# Altium schematic sheet Sata_Controll.SchDoc(Sata_Controll)
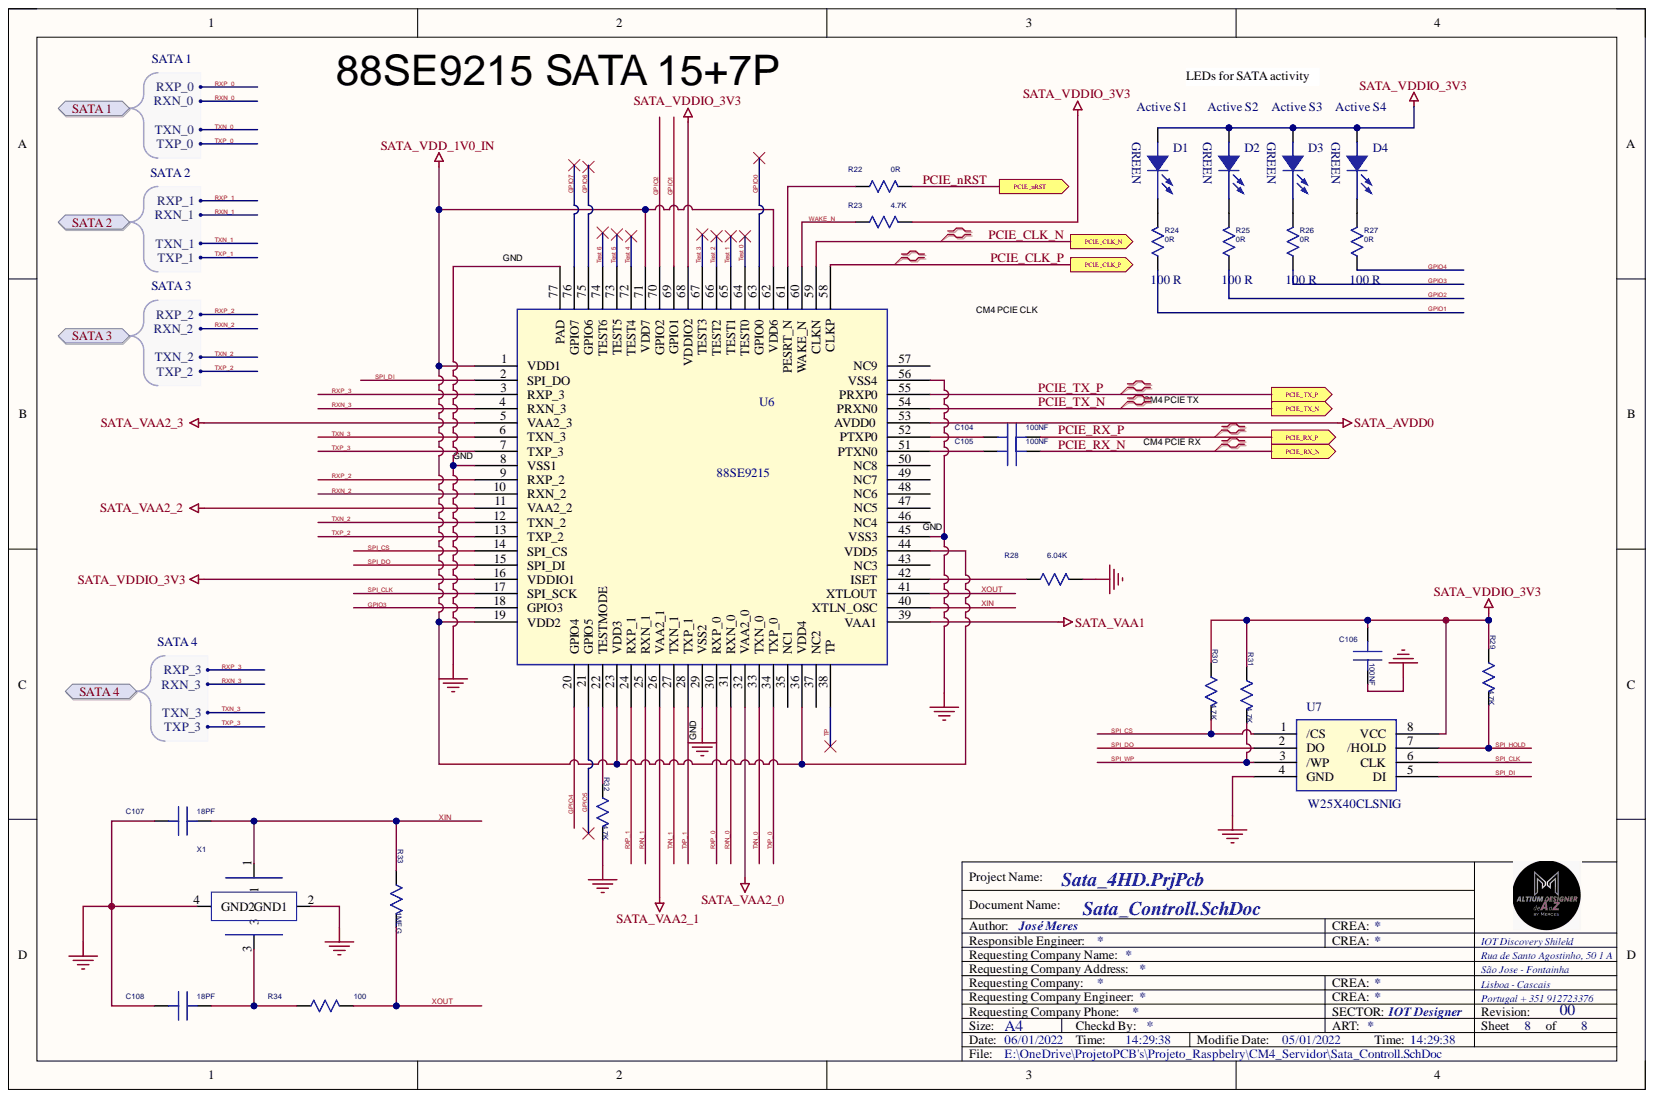
[diagram p0: Sata_Controll.SchDoc]

## Parts
C104  100NF  [C0402-ROUND]
C105  100NF  [C0402-ROUND]
C106  100NF  [C0402-ROUND]
C107  18PF  [C0402-ROUND]
C108  18PF  [C0402-ROUND]
D1  GREEN  [LED-0603]
D2  GREEN  [LED-0603]
D3  GREEN  [LED-0603]
D4  GREEN  [LED-0603]
R22  0R  [R0402-ROUND]
R23  4.7K  [R0402-ROUND]
R24  0R  value 100 R  [R0201-ROUND]
R25  0R  value 100 R  [R0201-ROUND]
R26  0R  value 100 R  [R0201-ROUND]
R27  0R  value 100 R  [R0201-ROUND]
R28  6.04K  [R0402-ROUND]
R29  4.7K  [R0402-ROUND]
R30  4.7K  [R0402-ROUND]
R31  4.7K  [R0402-ROUND]
R32  4.7K  [R0402-ROUND]
R33  1MEG  [R0402-ROUND]
R34  100  [R0402-ROUND]
U6  88SE9215  [Sata-88SE9215]
U7  W25X40CLSNIG  [SO08]
X1  25M  [HSX4-0302]  (value not drawn)

## Ports
PCIE_CLK_N, PCIE_CLK_P, PCIE_nRST, PCIE_RX_N, PCIE_RX_P, PCIE_TX_N, PCIE_TX_P, SATA 1, SATA 1.RXN_0, SATA 1.RXP_0, SATA 1.TXN_0, SATA 1.TXP_0, SATA 2, SATA 2.RXN_1, SATA 2.RXP_1, SATA 2.TXN_1, SATA 2.TXP_1, SATA 3, SATA 3.RXN_2, SATA 3.RXP_2, SATA 3.TXN_2, SATA 3.TXP_2, SATA 4, SATA 4.RXN_3, SATA 4.RXP_3, SATA 4.TXN_3, SATA 4.TXP_3

## Nets
GND: C106.2 C107.1 C108.1 R28.2 R32.2 U6.8(VSS1) U6.29(VSS2) U6.45(VSS3) U6.56(VSS4) U6.77(PAD) U7.4(GND) X1.2(GND1) X1.4(GND2)
SATA_AVDD0: U6.53(AVDD0)
SATA_VAA1: U6.39(VAA1)
SATA_VAA2_0: U6.32(VAA2_0)
SATA_VAA2_1: U6.26(VAA2_1)
SATA_VAA2_2: U6.11(VAA2_2)
SATA_VAA2_3: U6.5(VAA2_3)
SATA_VDD_1V0_IN: U6.1(VDD1) U6.19(VDD2) U6.23(VDD3) U6.36(VDD4) U6.44(VDD5) U6.62(VDD6) U6.71(VDD7)
SATA_VDDIO_3V3: C106.1 D1.1 D2.1 D3.1 D4.1 R23.2 R29.1 R30.1 R31.1 U6.16(VDDIO1) U6.68(VDDIO2) U7.8(VCC)
GPIO0: U6.63(GPIO0)
GPIO1: R24.1 U6.69(GPIO1)
GPIO2: R25.1 U6.70(GPIO2)
GPIO3: R26.1 U6.18(GPIO3)
GPIO4: R27.1 U6.20(GPIO4)
GPIO5: U6.21(GPIO5)
GPIO6: U6.75(GPIO6)
GPIO7: U6.76(GPIO7)
PCIE_CLK_N: U6.59(CLKN) ; port PCIE_CLK_N
PCIE_CLK_P: U6.58(CLKP) ; port PCIE_CLK_P
PCIE_nRST: R22.2 ; port PCIE_nRST
PCIE_RX_N: C105.2 ; port PCIE_RX_N
PCIE_RX_P: C104.2 ; port PCIE_RX_P
PCIE_TX_N: U6.54(PRXN0) ; port PCIE_TX_N
PCIE_TX_P: U6.55(PRXP0) ; port PCIE_TX_P
RXN_0: U6.31(RXN_0) ; port SATA 1
RXN_1: U6.25(RXN_1) ; port SATA 2
RXN_2: U6.10(RXN_2) ; port SATA 3
RXN_3: U6.4(RXN_3) ; port SATA 4
RXP_0: U6.30(RXP_0) ; port SATA 1
RXP_1: U6.24(RXP_1) ; port SATA 2
RXP_2: U6.9(RXP_2) ; port SATA 3
RXP_3: U6.3(RXP_3) ; port SATA 4
SPI_CLK: U6.17(SPI_SCK) U7.6(CLK)
SPI_CS: R30.2 U6.14(SPI_CS) U7.1(/CS)
SPI_DI: U6.2(SPI_DO) U7.5(DI)
SPI_DO: U6.15(SPI_DI) U7.2(DO)
SPI_HOLD: R29.2 U7.7(/HOLD)
SPI_WP: R31.2 U7.3(/WP)
Test 0: U6.64(TEST0)
Test 1: U6.65(TEST1)
Test 2: U6.66(TEST2)
Test 3: U6.67(TEST3)
Test 4: U6.72(TEST4)
Test 5: U6.73(TEST5)
Test 6: U6.74(TEST6)
TP: U6.38(TP)
TXN_0: U6.33(TXN_0) ; port SATA 1
TXN_1: U6.27(TXN_1) ; port SATA 2
TXN_2: U6.12(TXN_2) ; port SATA 3
TXN_3: U6.6(TXN_3) ; port SATA 4
TXP_0: U6.34(TXP_0) ; port SATA 1
TXP_1: U6.28(TXP_1) ; port SATA 2
TXP_2: U6.13(TXP_2) ; port SATA 3
TXP_3: U6.7(TXP_3) ; port SATA 4
WAKE_N: R23.1 U6.60(WAKE_N)
XIN: C107.2 R33.1 U6.40(XTLN_OSC) X1.1
XOUT: R33.2 R34.2 U6.41(XTLOUT)
NetC104_1: C104.1 U6.52(PTXP0)
NetC105_1: C105.1 U6.51(PTXN0)
NetC108_2: C108.2 R34.1 X1.3
NetD1_2: D1.2 R24.2
NetD2_2: D2.2 R25.2
NetD3_2: D3.2 R26.2
NetD4_2: D4.2 R27.2
NetR22_1: R22.1 U6.61(PESRT_N)
NetR28_1: R28.1 U6.42(ISET)
NetR32_1: R32.1 U6.22(TESTMODE)

Not connected: U6 35(NC1) 37(NC2) 43(NC3) 46(NC4) 47(NC5) 48(NC6) 49(NC7) 50(NC8) 57(NC9)

## Library data of the parts
C104 C105 C107 C108 = C_0_Dup3: PARTS: 1; %182%%238%%182%%168%%185%%164%%215%%247%%181%%231%%209%%185%(V): 50; %195%%232%%202%%246%: SMD陶瓷电容-50V-0.018nF-+/-5%-NPO-0402; %208%%161%%192%%224%%195%%251%%179%%198%: SMD陶瓷电容; CODE: 8070580; COMPONENT_HIGH_MAX: 0.55MM; DEVICE: C0402C180J5GAC_7867; LEVEL: STD; OriginalSymbolOrigin: (0,30); PCB Footprint: SC0402-BGA; PINSWAP: (A,B); PKG_TYPE: SC0402; Component Kind: Standard
C106 = C_0_Dup3: PARTS: 1; %182%%238%%182%%168%%185%%164%%215%%247%%181%%231%%209%%185%(V): 16; %195%%232%%202%%246%: SMD陶瓷电容-16V-100NF-+/-10%-X7R-0402-BT; %208%%161%%192%%224%%195%%251%%179%%198%: SMD陶瓷电容; CODE: 8070614; COMPONENT_HIGH_MAX: 0.55MM; DEVICE: RMEMK105BJ104KV_FR; LEVEL: STD; OriginalSymbolOrigin: (0,30); PCB Footprint: SC0402; PINSWAP: (A,B); VARIANT_ID: $37I1928; Component Kind: Standard; PKG_TYPE: SC0402
D1-D4 = LED_1: Component Kind: Standard; Library Name: Merces_Library.SchLib
R22-R32 = R_0_Dup2: PARTS: 1; %182%%238%%182%%168%%185%%166%%194%%202%(W): 0.1; %195%%232%%202%%246%: 片式厚膜电阻器-0.1W-12700OHM-+/-1%-0603--供电专用-07090924-供电专用; %208%%161%%192%%224%%195%%251%%179%%198%: 片式厚膜电阻器; %215%%232%%214%%181%%212%%202%%208%%237%%198%%171%%178%%238%: +/-1%; CODE: 7091203; COMPONENT_HIGH_MAX: 0.45MM; DEVICE: RC0603FR_0712K7L; LEVEL: STD; OriginalSymbolOrigin: (10,20); PCB Footprint: SR0402; PINSWAP: (A,B); PKG_TYPE: SR0603; Component Kind: Standard
R33 = R_0_Dup2: PARTS: 1; %182%%238%%182%%168%%185%%166%%194%%202%(W): 0.06; %195%%232%%202%%246%: 片式厚膜电阻器-0.0625W-1000000ohm-+/-1%-0402; %208%%161%%192%%224%%195%%251%%179%%198%: 片式厚膜电阻器; %215%%232%%214%%181%%212%%202%%208%%237%%198%%171%%178%%238%: +/-1%; CODE: 7090857; COMPONENT_HIGH_MAX: 0.4MM; DEVICE: RK73H1ETTP1004F; LEVEL: STD; OriginalSymbolOrigin: (10,20); PCB Footprint: SR0402; PINSWAP: (A,B); Component Kind: Standard; PKG_TYPE: SR0402
R34 = R_0_Dup2: PARTS: 1; %182%%238%%182%%168%%185%%166%%194%%202%(W): 0.06; %195%%232%%202%%246%: 片式厚膜电阻器-0.0625W-100ohm-+/-5%-0402-BT; %208%%161%%192%%224%%195%%251%%179%%198%: 片式厚膜电阻器; %215%%232%%214%%181%%212%%202%%208%%237%%198%%171%%178%%238%: +/-5%; CODE: 7090807; COMPONENT_HIGH_MAX: 0.4MM; DEVICE: RC0402JR_07100R; LEVEL: STD; OriginalSymbolOrigin: (10,20); PCB Footprint: SR0402; PINSWAP: (A,B); Component Kind: Standard; PKG_TYPE: SR0402
U6 = 88SE9215: PCB Footprint: QFN76P_P0D4_W9X9; Component Kind: Standard
U7 = W25X40CLSNIG: PCB Footprint: SOP8_P1D25_W5; Component Kind: Standard
X1 = X_B_0: PARTS: 1; CODE: 12020151; DEVICE: X_B; LEVEL: STD; OriginalSymbolOrigin: (20,80); Component Kind: Standard; PCB Footprint: HSX4-0302; PKG_TYPE: HSX4-0302
